# Altium schematic sheet P05_GSM 2 of 2.SchDoc
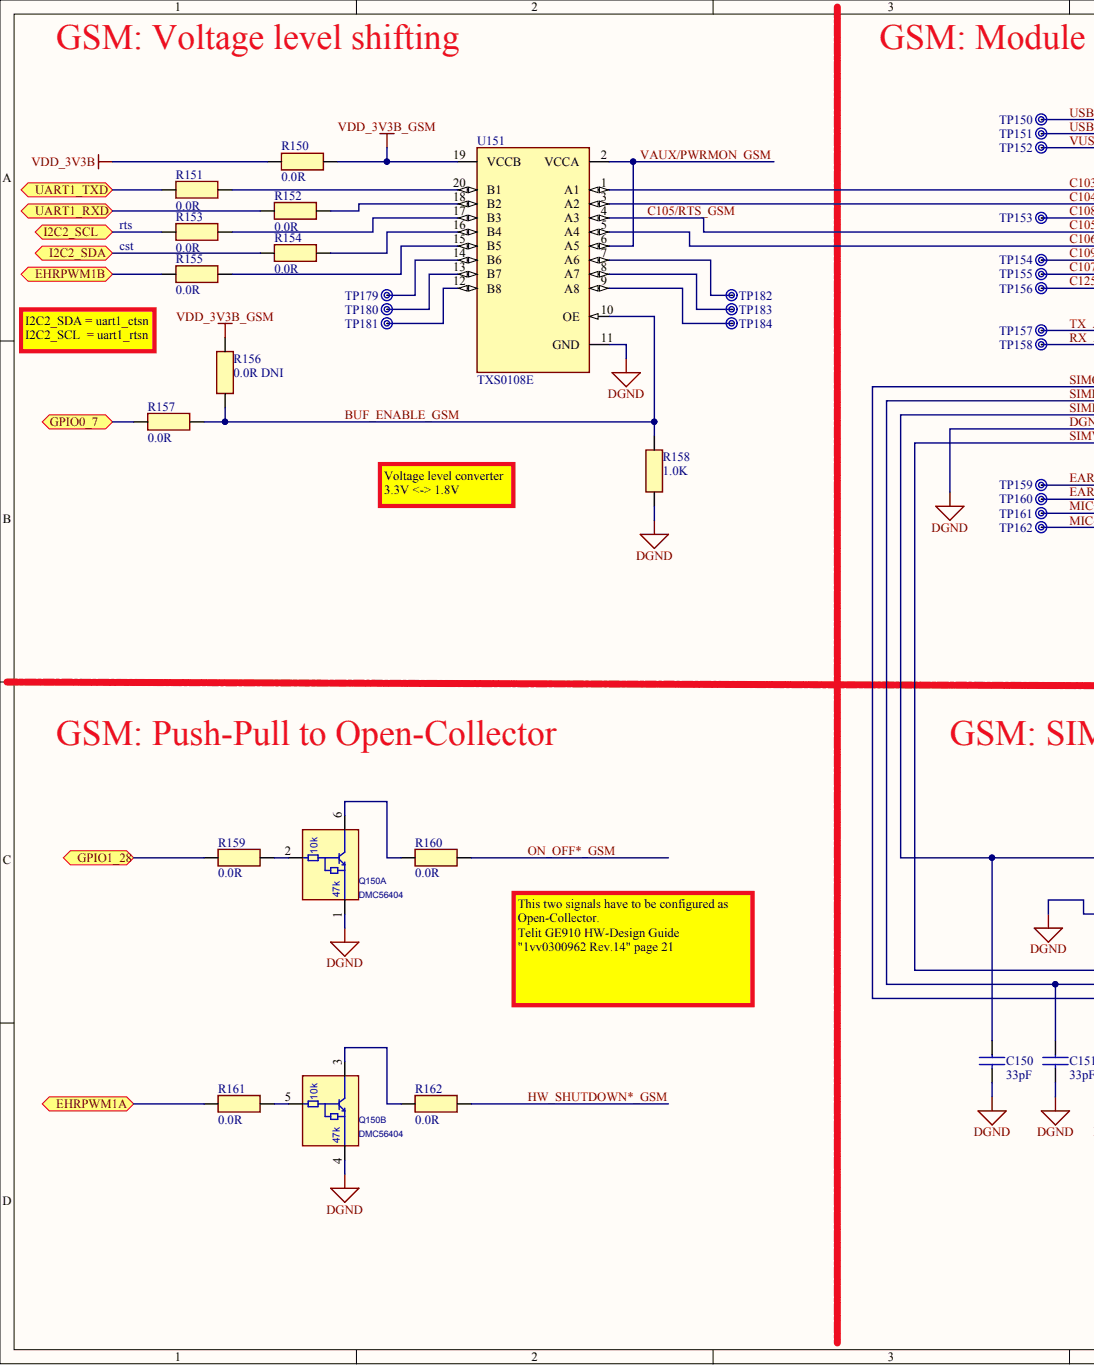
[diagram p0: P05_GSM 2 of 2.SchDoc, left]
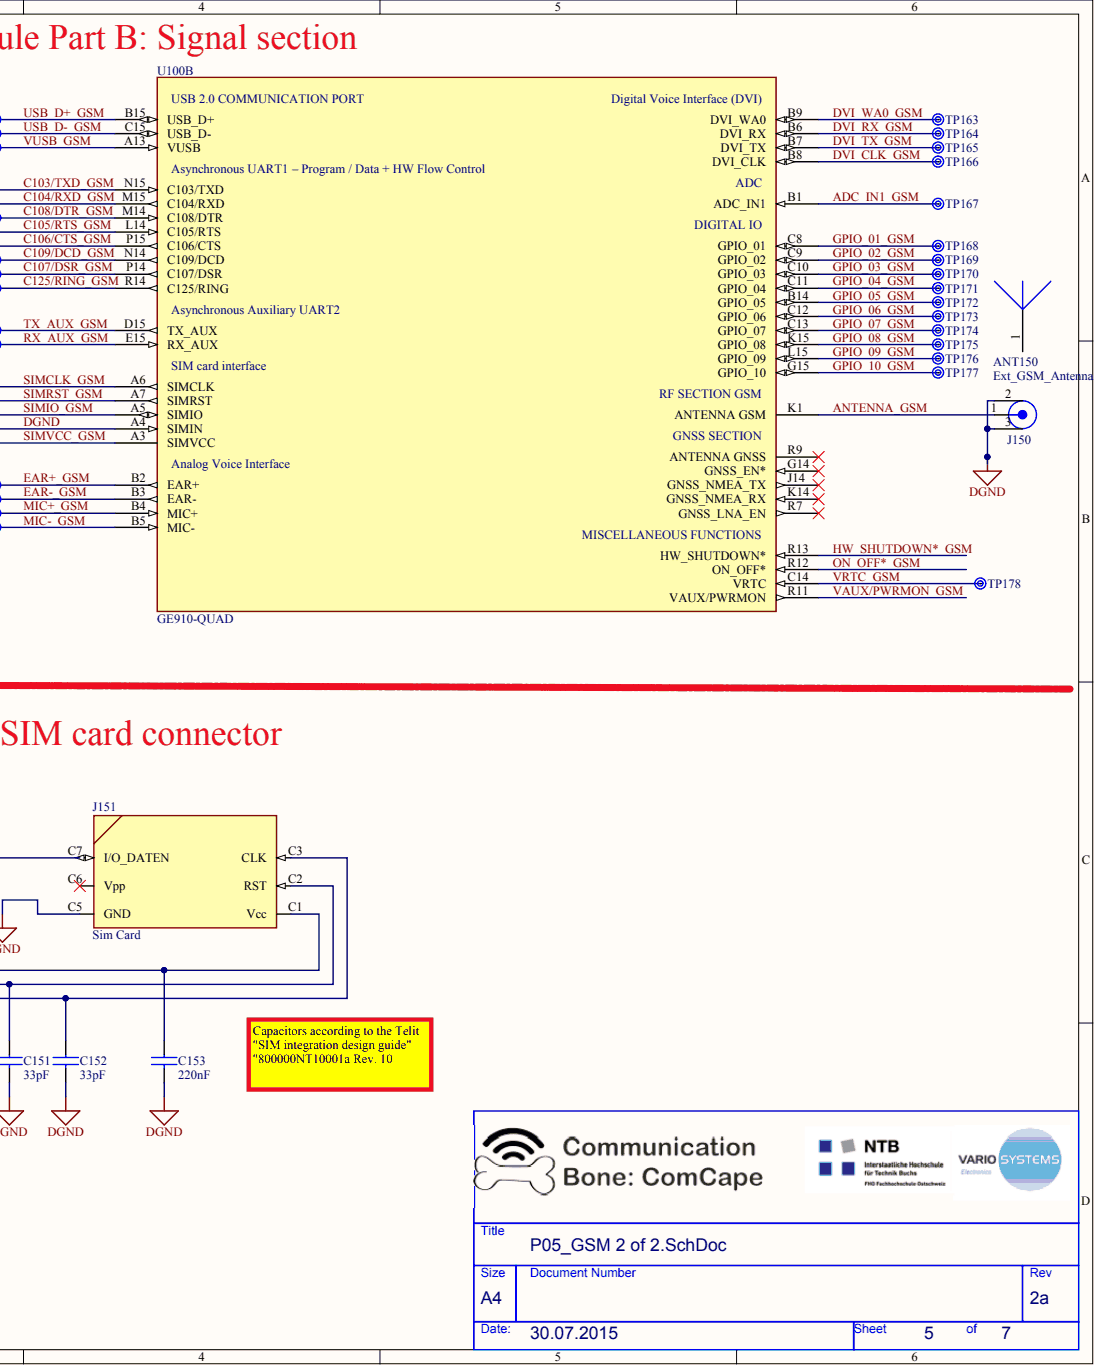
[diagram p1: P05_GSM 2 of 2.SchDoc, right]

## Parts
ANT150  Ext_GSM_Antenna  (mechanical)
C150  33pF  [SM/C_0603]
C151  33pF  [SM/C_0603]
C152  33pF  [SM/C_0603]
C153  220nF  [SM/C_0603]
J150  SMA_Buchse  [SMA_Kartenrand]  (value not drawn)
J151  Sim Card  [Sim Card Connector]
Q150  DMC56404  Resistor - Base (R1) (Ohms): 10k  Resistor - Emitter Base (R2) (Ohms): 47k  [SMini6-F3-B]  (parts A,B)
R150  0.0R  [SM/R_0603]
R151  0.0R  [SM/R_0603]
R152  0.0R  [SM/R_0603]
R153  0.0R  [SM/R_0603]
R154  0.0R  [SM/R_0603]
R155  0.0R  [SM/R_0603]
R156  0.0R DNI  value 0.0R  [SM/R_0603]
R157  0.0R  [SM/R_0603]
R158  1.0K  [SM/R_0603]
R159  0.0R  [SM/R_0603]
R160  0.0R  [SM/R_0603]
R161  0.0R  [SM/R_0603]
R162  0.0R  [SM/R_0603]
TP150  Testpoint mit Bohrung  [TP_mit_0.7mm_Bohrung]  (value not drawn)  (no BOM)
TP151  Testpoint mit Bohrung  [TP_mit_0.7mm_Bohrung]  (value not drawn)  (no BOM)
TP152  Testpoint mit Bohrung  [TP_mit_0.7mm_Bohrung]  (value not drawn)  (no BOM)
TP153  Testpoint mit Bohrung  [TP_mit_0.7mm_Bohrung]  (value not drawn)  (no BOM)
TP154  Testpoint mit Bohrung  [TP_mit_0.7mm_Bohrung]  (value not drawn)  (no BOM)
TP155  Testpoint mit Bohrung  [TP_mit_0.7mm_Bohrung]  (value not drawn)  (no BOM)
TP156  Testpoint mit Bohrung  [TP_mit_0.7mm_Bohrung]  (value not drawn)  (no BOM)
TP157  Testpoint mit Bohrung  [TP_mit_0.7mm_Bohrung]  (value not drawn)  (no BOM)
TP158  Testpoint mit Bohrung  [TP_mit_0.7mm_Bohrung]  (value not drawn)  (no BOM)
TP159  Testpoint mit Bohrung  [TP_mit_0.7mm_Bohrung]  (value not drawn)  (no BOM)
TP160  Testpoint mit Bohrung  [TP_mit_0.7mm_Bohrung]  (value not drawn)  (no BOM)
TP161  Testpoint mit Bohrung  [TP_mit_0.7mm_Bohrung]  (value not drawn)  (no BOM)
TP162  Testpoint mit Bohrung  [TP_mit_0.7mm_Bohrung]  (value not drawn)  (no BOM)
TP163  Testpoint mit Bohrung  [TP_mit_0.7mm_Bohrung]  (value not drawn)  (no BOM)
TP164  Testpoint mit Bohrung  [TP_mit_0.7mm_Bohrung]  (value not drawn)  (no BOM)
TP165  Testpoint mit Bohrung  [TP_mit_0.7mm_Bohrung]  (value not drawn)  (no BOM)
TP166  Testpoint mit Bohrung  [TP_mit_0.7mm_Bohrung]  (value not drawn)  (no BOM)
TP167  Testpoint mit Bohrung  [TP_mit_0.7mm_Bohrung]  (value not drawn)  (no BOM)
TP168  Testpoint mit Bohrung  [TP_mit_0.7mm_Bohrung]  (value not drawn)  (no BOM)
TP169  Testpoint mit Bohrung  [TP_mit_0.7mm_Bohrung]  (value not drawn)  (no BOM)
TP170  Testpoint mit Bohrung  [TP_mit_0.7mm_Bohrung]  (value not drawn)  (no BOM)
TP171  Testpoint mit Bohrung  [TP_mit_0.7mm_Bohrung]  (value not drawn)  (no BOM)
TP172  Testpoint mit Bohrung  [TP_mit_0.7mm_Bohrung]  (value not drawn)  (no BOM)
TP173  Testpoint mit Bohrung  [TP_mit_0.7mm_Bohrung]  (value not drawn)  (no BOM)
TP174  Testpoint mit Bohrung  [TP_mit_0.7mm_Bohrung]  (value not drawn)  (no BOM)
TP175  Testpoint mit Bohrung  [TP_mit_0.7mm_Bohrung]  (value not drawn)  (no BOM)
TP176  Testpoint mit Bohrung  [TP_mit_0.7mm_Bohrung]  (value not drawn)  (no BOM)
TP177  Testpoint mit Bohrung  [TP_mit_0.7mm_Bohrung]  (value not drawn)  (no BOM)
TP178  Testpoint mit Bohrung  [TP_mit_0.7mm_Bohrung]  (value not drawn)  (no BOM)
TP179  Testpoint mit Bohrung  [TP_mit_0.7mm_Bohrung]  (value not drawn)  (no BOM)
TP180  Testpoint mit Bohrung  [TP_mit_0.7mm_Bohrung]  (value not drawn)  (no BOM)
TP181  Testpoint mit Bohrung  [TP_mit_0.7mm_Bohrung]  (value not drawn)  (no BOM)
TP182  Testpoint mit Bohrung  [TP_mit_0.7mm_Bohrung]  (value not drawn)  (no BOM)
TP183  Testpoint mit Bohrung  [TP_mit_0.7mm_Bohrung]  (value not drawn)  (no BOM)
TP184  Testpoint mit Bohrung  [TP_mit_0.7mm_Bohrung]  (value not drawn)  (no BOM)
U100  GE910-QUAD  [LGA144C180P15X15_2820X2820X225]  (part B)
U151  TXS0108E  [TSSOP.65M/20/WG.252/L.255]

## Ports
EHRPWM1A, EHRPWM1B, GPIO0_7, GPIO1_28, I2C2_SCL, I2C2_SDA, UART1_RXD, UART1_TXD

## Nets
VDD_3V3B: R150.1
VDD_3V3B_GSM: R150.2 R156.1 U151.19(VCCB)
ADC_IN1_GSM: TP167.1 U100.B1(ADC_IN1)
ANTENNA_GSM: J150.1 U100.K1(ANTENNA_GSM)
BUF_ENABLE_GSM: R156.2 R157.2 R158.1 U151.10(OE)
C103/TXD_GSM: U100.N15(C103/TXD) U151.1(A1)
C104/RXD_GSM: U100.M15(C104/RXD) U151.3(A2)
C105/RTS_GSM: U100.L14(C105/RTS) U151.4(A3)
C106/CTS_GSM: U100.P15(C106/CTS) U151.5(A4)
C107/DSR_GSM: TP155.1 U100.P14(C107/DSR)
C108/DTR_GSM: TP153.1 U100.M14(C108/DTR)
C109/DCD_GSM: TP154.1 U100.N14(C109/DCD)
C125/RING_GSM: TP156.1 U100.R14(C125/RING)
DGND: C150.2 C151.2 C152.2 C153.2 J150.2 J150.3 J151.C5(GND) Q150.1 Q150.4 R158.2 U100.A4(SIMIN) U151.11(GND)
DVI_CLK_GSM: TP166.1 U100.B8(DVI_CLK)
DVI_RX_GSM: TP164.1 U100.B6(DVI_RX)
DVI_TX_GSM: TP165.1 U100.B7(DVI_TX)
DVI_WA0_GSM: TP163.1 U100.B9(DVI_WA0)
EAR+_GSM: TP159.1 U100.B2(EAR+)
EAR-_GSM: TP160.1 U100.B3(EAR-)
EHRPWM1A: R161.1 ; port EHRPWM1A
EHRPWM1B: R155.1 ; port EHRPWM1B
GPIO0_7: R157.1 ; port GPIO0_7
GPIO1_28: R159.1 ; port GPIO1_28
GPIO_01_GSM: TP168.1 U100.C8(GPIO_01)
GPIO_02_GSM: TP169.1 U100.C9(GPIO_02)
GPIO_03_GSM: TP170.1 U100.C10(GPIO_03)
GPIO_04_GSM: TP171.1 U100.C11(GPIO_04)
GPIO_05_GSM: TP172.1 U100.B14(GPIO_05)
GPIO_06_GSM: TP173.1 U100.C12(GPIO_06)
GPIO_07_GSM: TP174.1 U100.C13(GPIO_07)
GPIO_08_GSM: TP175.1 U100.K15(GPIO_08)
GPIO_09_GSM: TP176.1 U100.L15(GPIO_09)
GPIO_10_GSM: TP177.1 U100.G15(GPIO_10)
HW_SHUTDOWN*_GSM: R162.2 U100.R13(HW_SHUTDOWN*)
I2C2_SCL: R153.1 ; port I2C2_SCL
I2C2_SDA: R154.1 ; port I2C2_SDA
MIC+_GSM: TP161.1 U100.B4(MIC+)
MIC-_GSM: TP162.1 U100.B5(MIC-)
ON_OFF*_GSM: R160.2 U100.R12(ON_OFF*)
RX_AUX_GSM: TP158.1 U100.E15(RX_AUX)
SIMCLK_GSM: C152.1 J151.C3(CLK) U100.A6(SIMCLK)
SIMIO_GSM: C150.1 J151.C7(I/O_DATEN) U100.A5(SIMIO)
SIMRST_GSM: C151.1 J151.C2(RST) U100.A7(SIMRST)
SIMVCC_GSM: C153.1 J151.C1(Vcc) U100.A3(SIMVCC)
TX_AUX_GSM: TP157.1 U100.D15(TX_AUX)
UART1_RXD: R152.1 ; port UART1_RXD
UART1_TXD: R151.1 ; port UART1_TXD
USB_D+_GSM: TP150.1 U100.B15(USB_D+)
USB_D-_GSM: TP151.1 U100.C15(USB_D-)
VAUX/PWRMON_GSM: U100.R11(VAUX/PWRMON) U151.2(VCCA) U151.6(A5)
VRTC_GSM: TP178.1 U100.C14(VRTC)
VUSB_GSM: TP152.1 U100.A13(VUSB)
NetQ150_2: Q150.2 R159.2
NetQ150_3: Q150.3 R162.1
NetQ150_5: Q150.5 R161.2
NetQ150_6: Q150.6 R160.1
NetR151_2: R151.2 U151.20(B1)
NetR152_2: R152.2 U151.18(B2)
NetR153_2: R153.2 U151.17(B3)
NetR154_2: R154.2 U151.16(B4)
NetR155_2: R155.2 U151.15(B5)
NetTP179_1: TP179.1 U151.14(B6)
NetTP180_1: TP180.1 U151.13(B7)
NetTP181_1: TP181.1 U151.12(B8)
NetTP182_1: TP182.1 U151.7(A6)
NetTP183_1: TP183.1 U151.8(A7)
NetTP184_1: TP184.1 U151.9(A8)

Not connected: ANT150 1; J151 C6(Vpp); U100 G14(GNSS_EN*) J14(GNSS_NMEA_TX) K14(GNSS_NMEA_RX) R7(GNSS_LNA_EN) R9(ANTENNA_GNSS)

## Library data of the parts
ANT150 = Ext_GSM_Antenna: GSM ANTENNA ANTA2000A0200BR11; Supplier 1: Mouser; Supplier 2: Digi-Key; Supplier Part Number 1: 782-X000003; Supplier Part Number 2: 1050-1114-ND; Library Name: ComCape.SchLib
C150-C152 = SC CAP __0603 _33p00 _50V ____5% C0G ___: Manufacturer: Generic Component; Part Number: 203096; Cust: Y; HTNr: take notice of key data; Std: STD SET; Tolerance: 5%; Voltage: 50V; Component Kind: Standard; Description: SC CAP __0603 _33p00 _50V ____5% C0G ___; Part Type: CAP-SMD\Keramik\0603; PCB Footprint: SM/C_0603; Supplier 1: Digi-Key; Supplier Part Number 1: 399-1055-1-ND; Value: 33pF; Library Name: ComCape.SchLib
C153 = SC CAP __0603 220N00 _10V ___10% X7R ___: Manufacturer: Generic Component; Part Number: 235417; Cust: Y; HTNr: take notice of key data; Tolerance: 10%; Voltage: 10V; Component Kind: Standard; Description: SC CAP __0603 220N00 _10V ___10% X7R ___; Part Type: CAP-SMD\Keramik\0603; Std: STD; Supplier 1: Digi-Key; Supplier Part Number 1: 311-1346-1-ND; Library Name: ComCape.SchLib
J150 = SMA_Buchse: CONN SMA JACK 50 OHM EDGE MNT; Component Kind: Standard; Supplier 1: Digi-Key; Supplier Part Number 1: CONSMA003.062-ND; Library Name: ComCape.SchLib
J151 = SimCardConnector_WR-CRD_Mini_Sim: Sim Card Connector; Manufacturer: Wurth Electronics Inc; HTNr: 693012040811; Component Kind: Standard; Supplier 1: Digi-Key; Supplier Part Number 1: 732-4289-1-ND; Library Name: ComCape.SchLib
Q150 = RN1907: TRANS PREBIASED DUAL NPN SOT363; Manufacturer: Toshiba; Manufacturer Part Number: DDC114YU-7-F; ATLStockNo1: 2130.0137; ATLStockNo2: 2130.01; Current - Collector (Ic) (Max): 100mA; Current - Collector Cutoff (Max): 500nA; DC Current Gain (hFE) (Min) @ Ic, Vce: 68 @ 10mA, 5V; Frequency - Transition: 250MHz; Order# 2: BCR135S; Power - Max: 200mW; Transistor Type: 2 NPN - Pre-Biased (Dual); Vce Saturation (Max) @ Ib, Ic: 300mV @ 250µA, 5mA; Voltage - Collector Emitter Breakdown (Max): 50V; Category: Discrete Semiconductor Products; Component Kind: Standard; ComponentLink1Description: http://www.diodes.com/datasheets/ds30345.pdf; ComponentLink1URL: http://www.diodes.com/datasheets/ds30345.pdf; Mounting Type: Surface Mount; Package / Case: 6-TSSOP, SC-88, SOT-363; Packaging: Digi-Reel®; Pricing 1: 1=0.46, 10=0.334, 25=0.2596, 100=0.1966, 250=0.13896, 500=0.11124, 1000=0.08532 (USD); RoHS: RoHS Compliant; Stock 1: 11411; Supplier 1: Digi-Key; Supplier Device Package: SOT-363; Supplier Part Number 1: DDC114YU-FDIDKR-ND; Library Name: BEAGLEBONEBLACK_REV A5B.SCHLIB
R150-R157 R159-R162 = SC RES __0603 __0R00 __0W1 _____ T100 __: Manufacturer: Generic Component; Part Number: 206748; Cust: Y; HTNr: take notice of key data; Power: 0.1W; Std: STD SET; Tolerance: 1%; Component Kind: Standard; Description: SC RES __0603 __0R00 __0W1 _____ T100 __; Part Type: RES-SMD\0603; PCB Footprint: SM/R_0603; Supplier 1: Digi-Key; Supplier Part Number 1: 1276-3510-1-ND; Library Name: ComCape.SchLib
  R150-R155 R157 R159-R162: Value: 0.0R
R158 = SC RES __0603 __1K00 __0W1 ___1% T100 __: Manufacturer: Generic Component; Part Number: 200139; Cust: Y; HTNr: take notice of key data; Power: 0.1W; Std: STD SET; Tolerance: 1%; Component Kind: Standard; Description: SC RES __0603 __1K00 __0W1 ___1% T100 __; Part Type: RES-SMD\0603; PCB Footprint: SM/R_0603; Supplier 1: Digi-Key; Supplier Part Number 1: 1276-3484-1-ND; Value: 1.0K; Library Name: ComCape.SchLib
TP150-TP184 = Testpoint mit Bohrung: TP_0.7mm_THRU; Library Name: ComCape.SchLib
U100 = GE910-QUAD: GSM Module; Manufacturer: Telit; Component Kind: Standard; Manufacturer Part Number: GE910-QUAD; Mounting Type: Surface Mount; Package / Case: 144-LGA; RoHS: RoHS Compliant; Library Name: ComCape.SchLib
U151 = TXS0108E: IC 8BIT NON-INV TRANSLTR 20TSSOP; Manufacturer: Texas Instruments; HTNr: TXS0108EPWR; Component Kind: Standard; Datasheet: http://www.ti.com/lit/ds/symlink/txs0108e.pdf; DigiKey Part Number: 296-23011-2-ND; Supplier 1: Digi-Key; Supplier Part Number 1: 296-23011-1-ND; Library Name: ComCape.SchLib
